# Altium schematic sheet Connectors.SchDoc
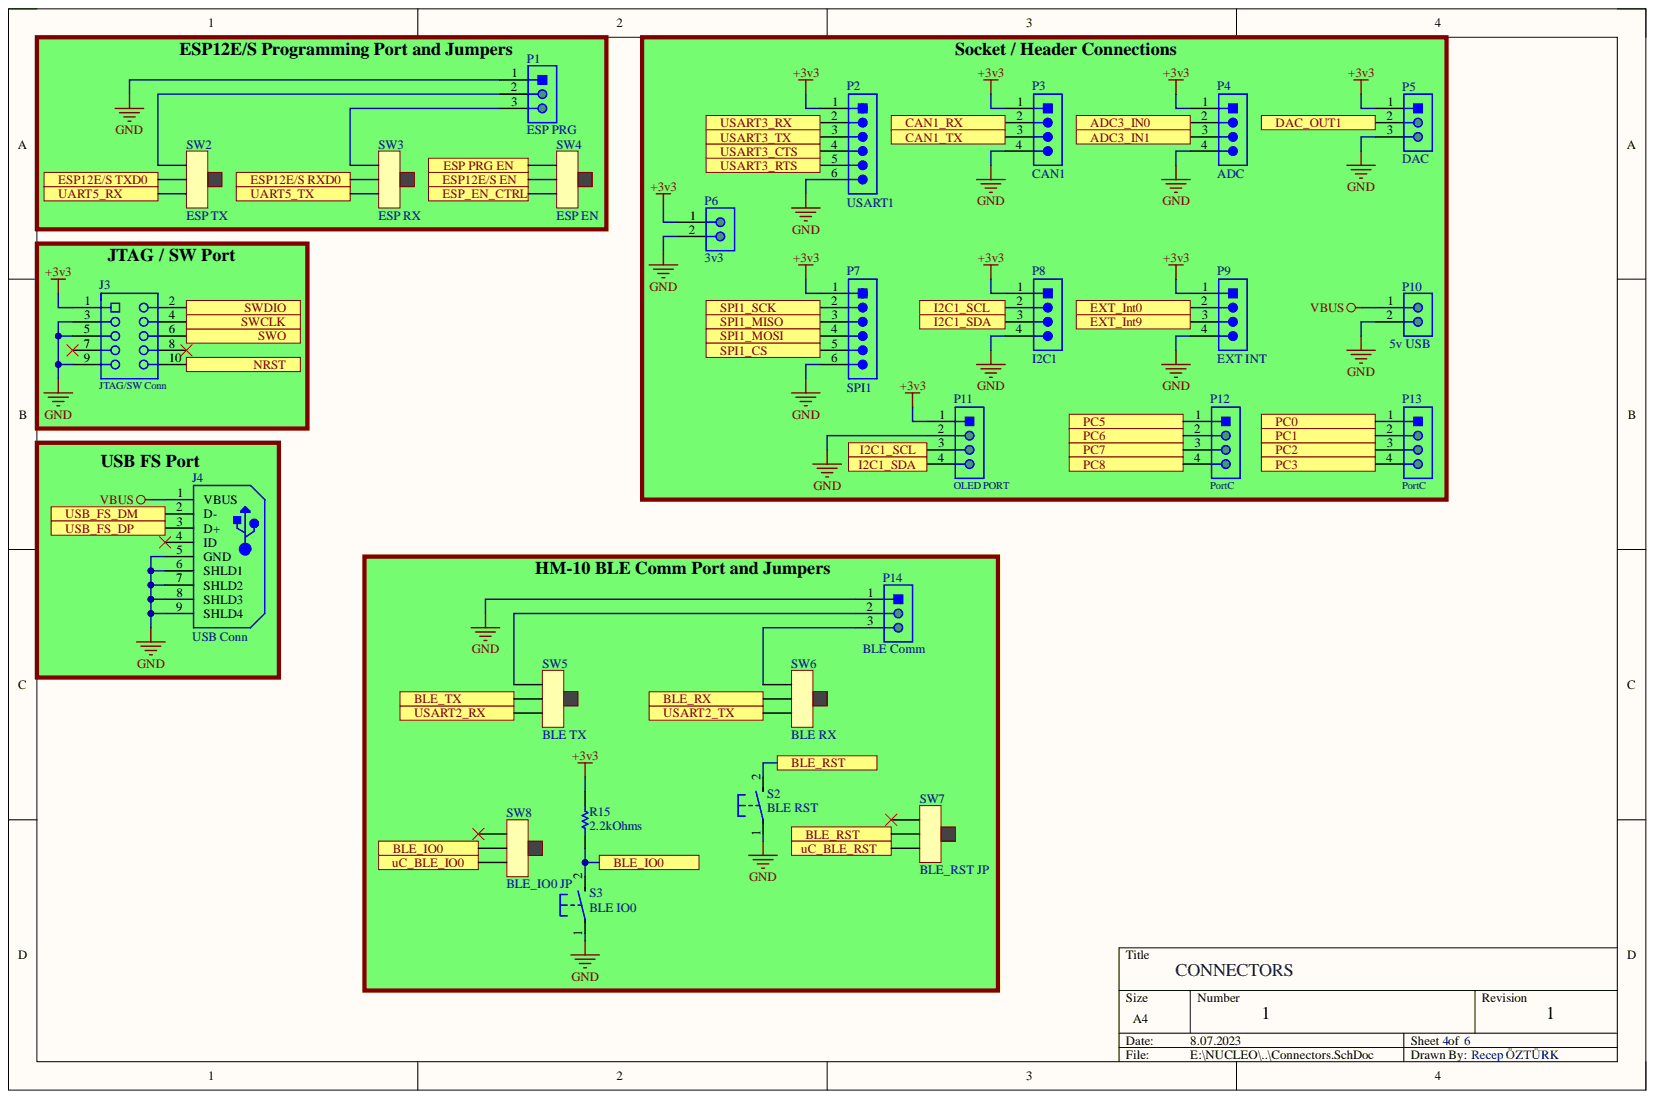
[diagram p0: Connectors.SchDoc]

## Parts
J3  JTAG/SW Conn  [61301021821]
J4  USB Conn  [WE-65100516121_V]
P1  ESP PRG  [TECO-640456-3]
P2  USART1  [MOLX-22-23-2061_V]
P3  CAN1  [MOLX-22-27-2041_V]
P4  ADC  [MOLX-22-27-2041_V]
P5  DAC  [TECO-640456-3]
P6  3v3  [MOLX-22-23-2021_V]
P7  SPI1  [MOLX-22-23-2061_V]
P8  I2C1  [MOLX-22-27-2041_V]
P9  EXT INT  [MOLX-22-27-2041_V]
P10  5v USB  [MOLX-22-23-2021_V]
P11  OLED PORT  [61300411121]
P12  PortC  [61300411121]
P13  PortC  [61300411121]
P14  BLE Comm  [TECO-640456-3]
R15  value 2.2kOhms  [RESC1608X50N]  (hidden comment: Res1)
S2  BLE RST  [DTS-3]
S3  BLE IO0  [DTS-3]
SW2  ESP TX  [Pololu Mini Slide SW 2.5mm]
SW3  ESP RX  [Pololu Mini Slide SW 2.5mm]
SW4  ESP EN  [Pololu Mini Slide SW 2.5mm]
SW5  BLE TX  [Pololu Mini Slide SW 2.5mm]
SW6  BLE RX  [Pololu Mini Slide SW 2.5mm]
SW7  BLE_RST JP  [Pololu Mini Slide SW 2.5mm]
SW8  BLE_IO0 JP  [Pololu Mini Slide SW 2.5mm]

## Ports
ADC3_IN0, ADC3_IN1, BLE_IO0, BLE_RST, BLE_RX, BLE_TX, CAN1_RX, CAN1_TX, DAC_OUT1, ESP12E/S EN, ESP12E/S RXD0, ESP12E/S TXD0, ESP PRG EN, ESP_EN_CTRL, EXT_Int0, EXT_Int9, I2C1_SCL, I2C1_SDA, NRST, PC0, PC1, PC2, PC3, PC5, PC6, PC7, PC8, SPI1_CS, SPI1_MISO, SPI1_MOSI, SPI1_SCK, SWCLK, SWDIO, SWO, UART5_RX, UART5_TX, uC_BLE_IO0, uC_BLE_RST, USART2_RX, USART2_TX, USART3_CTS, USART3_RTS, USART3_RX, USART3_TX, USB_FS_DM, USB_FS_DP

## Nets
+3v3: J3.1 P2.1 P3.1 P4.1 P5.1 P6.1 P7.1 P8.1 P9.1 P11.1 R15.2
GND: J3.3 J3.5 J3.9 J4.5(GND) J4.6(SHLD1) J4.7(SHLD2) J4.8(SHLD3) J4.9(SHLD4) P1.1 P2.6 P3.4 P4.4 P5.3 P6.2 P7.6 P8.4 P9.4 P10.2 P11.2 P14.1 S2.1 S3.1
VBUS: J4.1(VBUS) P10.1
ADC3_IN0: P4.2 ; port ADC3_IN0
ADC3_IN1: P4.3 ; port ADC3_IN1
BLE_IO0: R15.1 S3.2 SW8.2 ; port BLE_IO0
BLE_RST: S2.2 SW7.2 ; port BLE_RST
BLE_RX: SW6.2 ; port BLE_RX
BLE_TX: SW5.2 ; port BLE_TX
CAN1_RX: P3.2 ; port CAN1_RX
CAN1_TX: P3.3 ; port CAN1_TX
DAC_OUT1: P5.2 ; port DAC_OUT1
ESP12E/S EN: SW4.2 ; port ESP12E/S EN
ESP12E/S RXD0: SW3.2 ; port ESP12E/S RXD0
ESP12E/S TXD0: SW2.2 ; port ESP12E/S TXD0
ESP PRG EN: SW4.1 ; port ESP PRG EN
ESP_EN_CTRL: SW4.3 ; port ESP_EN_CTRL
EXT_Int0: P9.2 ; port EXT_Int0
EXT_Int9: P9.3 ; port EXT_Int9
I2C1_SCL: P8.2 P11.3 ; port I2C1_SCL
I2C1_SDA: P8.3 P11.4 ; port I2C1_SDA
NRST: J3.10 ; port NRST
PC0: P13.1 ; port PC0
PC1: P13.2 ; port PC1
PC2: P13.3 ; port PC2
PC3: P13.4 ; port PC3
PC5: P12.1 ; port PC5
PC6: P12.2 ; port PC6
PC7: P12.3 ; port PC7
PC8: P12.4 ; port PC8
SPI1_CS: P7.5 ; port SPI1_CS
SPI1_MISO: P7.3 ; port SPI1_MISO
SPI1_MOSI: P7.4 ; port SPI1_MOSI
SPI1_SCK: P7.2 ; port SPI1_SCK
SWCLK: J3.4 ; port SWCLK
SWDIO: J3.2 ; port SWDIO
SWO: J3.6 ; port SWO
UART5_RX: SW2.3 ; port UART5_RX
UART5_TX: SW3.3 ; port UART5_TX
uC_BLE_IO0: SW8.3 ; port uC_BLE_IO0
uC_BLE_RST: SW7.3 ; port uC_BLE_RST
USART2_RX: SW5.3 ; port USART2_RX
USART2_TX: SW6.3 ; port USART2_TX
USART3_CTS: P2.4 ; port USART3_CTS
USART3_RTS: P2.5 ; port USART3_RTS
USART3_RX: P2.2 ; port USART3_RX
USART3_TX: P2.3 ; port USART3_TX
USB_FS_DM: J4.2(D-) ; port USB_FS_DM
USB_FS_DP: J4.3(D+) ; port USB_FS_DP
NetP1_2: P1.2 SW2.1
NetP1_3: P1.3 SW3.1
NetP14_2: P14.2 SW5.1
NetP14_3: P14.3 SW6.1

Not connected: J3 7 8; J4 4(ID); SW7 1; SW8 1

## Library data of the parts
J3 = CMP-1502-01334-1: THT Vertical Socket Header WR-PHD, Pitch 2.54 mm, Dual Row, 10 pins; Manufacturer: Wurth Elektronik; Contact Resistance: 20mOhm; Gender: Female; Pin Number: 10; Pitch: 2.54mm; Rated Current: 3A; Withstanding Voltage: 500VAC; Working Voltage: 250VAC; Component Kind: Standard; Datasheet URL: https://katalog.we-online.de/em/datasheet/6130xx21821.pdf; Library Name: Altium Content Vault; Manufacturer URL: http://www.we-online.de; Mounting Technology: THT; Operating Temperature: -40to125degC; Orientation: Vertical; Package Reference: 61301021821; Packaging: Tray; Part Number: 61301021821; Row Number: Dual; Publisher: WurthElektronik
J4 = CMP-2000-05576-1: MINI USB 2.0 SMT Type B 5 Contacts, Direnc.net; Manufacturer: Wuerth Elektronik; Component Kind: Standard; Datasheet URL: https://datasheet.ciiva.com/4598/65100516121-83749-4598775.pdf; Datasheet Version: Rev. H, 02/2013; Library Name: Altium Content Vault; Manufacturer URL: https://www.we-online.com/; Mounting Technology: SurfaceMount; Package Description: 5-Pin Surface Mount Device, Body 9.6 x 9.25 mm, Pitch 0.8 mm; Package Reference: 65100516121
P1 P5 P14 = CMP-2000-05418-1: Male Header, Pitch 2.54 mm, 1 x 3; Manufacturer: TE Connectivity; Agency Approvals: CSA,UL; Angle: Straight; Brand/Series: MTA-100Series; Color, Housing: White; Connector Type: MTA-100; Contact Centerline Spacing: 0.100    in.; Contact Termination Area Plating: Tin; Current, Rating: 5    A; Material, Contact: CopperAlloy; Material, Housing: Polyester; Mount Angle: Vertical; Mounting Hole Size: 0.04    "; Number of Contacts: 3; Pin Spacing: 0.1    "; Primary Type: InterconnectSystem; Special Features: Pinstrip; Standards: RoHSCompliant,ELVCompliant,UL94V-0; Temperature, Operating: -55to+105    degC; Voltage, Rating: 250    V; Color: White; Component Kind: Standard; Contact Mating Area Plating: Tin; Contact Plating: Tin; Contact Shape: Square; Datasheet URL: https://datasheet.ciiva.com/16456/eng-ds-82056-mta-0608-16456886.pdf; Datasheet Version: Jun-08; Flammability Rating: UL94V-0; Length, Overall: 0.3    "; Length, Pin: 0.14    "; Length, Terminal Post: 0.14    in.; Library Name: Altium Content Vault; Manufacturer URL: http://www.te.com/; Mounting Type: PCMount; Number of Positions: 3; Number of Rows: 1; Size, Post: 0.025    in.; Termination: Solder; Type: PCBPlug
P2 P7 = CMP-2000-05536-1: Male Header, Pitch 2.54 mm, 1 x 6 Position,; Brand: Molex; Current Rating: 4A; Mounting Angle: Vertical; Number of Positions: 6; Pitch: 2.54mm; Voltage Rating: 250V; Component Kind: Standard; Contact Material: Brass; Contact Plating: Tin; Datasheet URL: https://datasheet.ciiva.com/19460/232303-19460895.pdf; Datasheet Version: Rev. P, 09/2001; Factory Pack Quantity: 1000; Housing Material: Nylon; Library Name: Altium Content Vault; Manufacturer: Molex; Manufacturer URL: http://www.molex.com/; Mounting Style: ThroughHole; Mounting Technology: ThroughHole; Number of Positions / Contacts: 6; Number of Rows: 1; Package Description: Male Header, Pitch 2.54 mm, 1 x 6 Position, Height 10.67 mm, Tail Length 3.56 mm; Package Reference: 22-23-2061; Packaging: Bulk; Product Category: .100K.K.Connectors; Product Type: Headers; RoHS: Details; Series: 6373; Termination Style: ThroughHole; Tradename: KK; Type: PinStrip
P3 P4 P8 P9 = CMP-2000-05243-1: Male Header, Pitch 2.54 mm, 1 x 4; Manufacturer: Molex; Current rating: 4A; Voltage rating: 250V; Component Kind: Standard; Contact material: Tinplatedbrass; Datasheet URL: http://www.molex.com/pdm_docs/sd/022272041_sd.pdf; Datasheet Version: Rev. F, 06/2009; Housing material: Nylon(UL94V-0); Library Name: Altium Content Vault; Manufacturer URL: http://www.molex.com/; Operating temperature: 0degCto+75degC
P6 P10 = CMP-1704-00001-3: Male Header, Pitch 2.54 mm, 1 x 2 Position, Height 10.67 mm, Tail Length 3.56 mm, 0 to 75 degC, RoHS, Bulk; Component Kind: Standard; ComponentLink1Description: Manufacturer URL; ComponentLink1URL: http://www.molex.com/; ComponentLink2Description: Datasheet; ComponentLink2URL: https://datasheet.ciiva.com/19454/232266-19454304.pdf; Height: 10.67 mm; Length: 5.08 mm; Library Name: Altium Content Vault; Max Operating Temperature: 75 degC; Min Operating Temperature: 0 degC; Mount: Through Hole; Mounting Technology: Through Hole; Package Quantity: 3000; PackageDescription: Male Header, Pitch 2.54 mm, 1 x 2 Position, Height 10.67 mm, Tail Length 3.56 mm; Packaging: Bulk; PartNumber: 22-23-2021; Pins: 2; Radiation Hardening: No; REACH SVHC: Unknown; RoHSCompliant: True; Width: 6.35 mm
P11-P13 = CMP-1502-01064-1: THT Vertical Pin Header WR-PHD, Pitch 2.54 mm, Single Row, 4 pins; Manufacturer: Wurth Elektronik; Contact Resistance: 20mOhm; Gender: Male; Pin Number: 4; Pitch: 2.54mm; Rated Current: 3A; Withstanding Voltage: 500VAC; Working Voltage: 250VAC; Component Kind: Standard; Datasheet URL: https://katalog.we-online.de/em/datasheet/6130xx11121.pdf; Library Name: Altium Content Vault; Manufacturer URL: http://www.we-online.de; Mounting Technology: THT; Operating Temperature: -40to105degC; Orientation: Vertical; Package Reference: 61300411121; Packaging: Bag; Part Number: 61300411121; Row Number: Single; Publisher: WurthElektronik
R15 = Res1: Chip Resistor - Surface Mount 2.2KOhms ±1% 1/10W 0603 RoHS C4190; Component Kind: Standard; LatestRevisionDate: 17-Jul-2002; LatestRevisionNote: Re-released for DXP Platform.; Library Name: Miscellaneous Devices.IntLib; PackageDescription: Axial Device, Thru-Hole; 2 Leads; 0.3 in Pin Spacing; PackageReference: AXIAL-0.3; Published: 8-Jun-2000; Signal Integrity: Res; Simulation: RESISTOR; Publisher: Altium Limited
S2 S3 = DTS-3: MF: {'manufacturer': u'APEM Inc.'}; Availability: Unavailable; Component Kind: Standard; Library Name: SnapEDA_Library.IntLib; MP: DTS-3; Package: None; Price: None
SW2-SW8 = Mini Slide Switch 2mm: 3 Pin SPDT Mini On Off Switch; Component Kind: Standard; Library Name: Schlib1.SchLib
